# Altium schematic sheet 1.1.6_Interface_board_Sensors.SchDoc
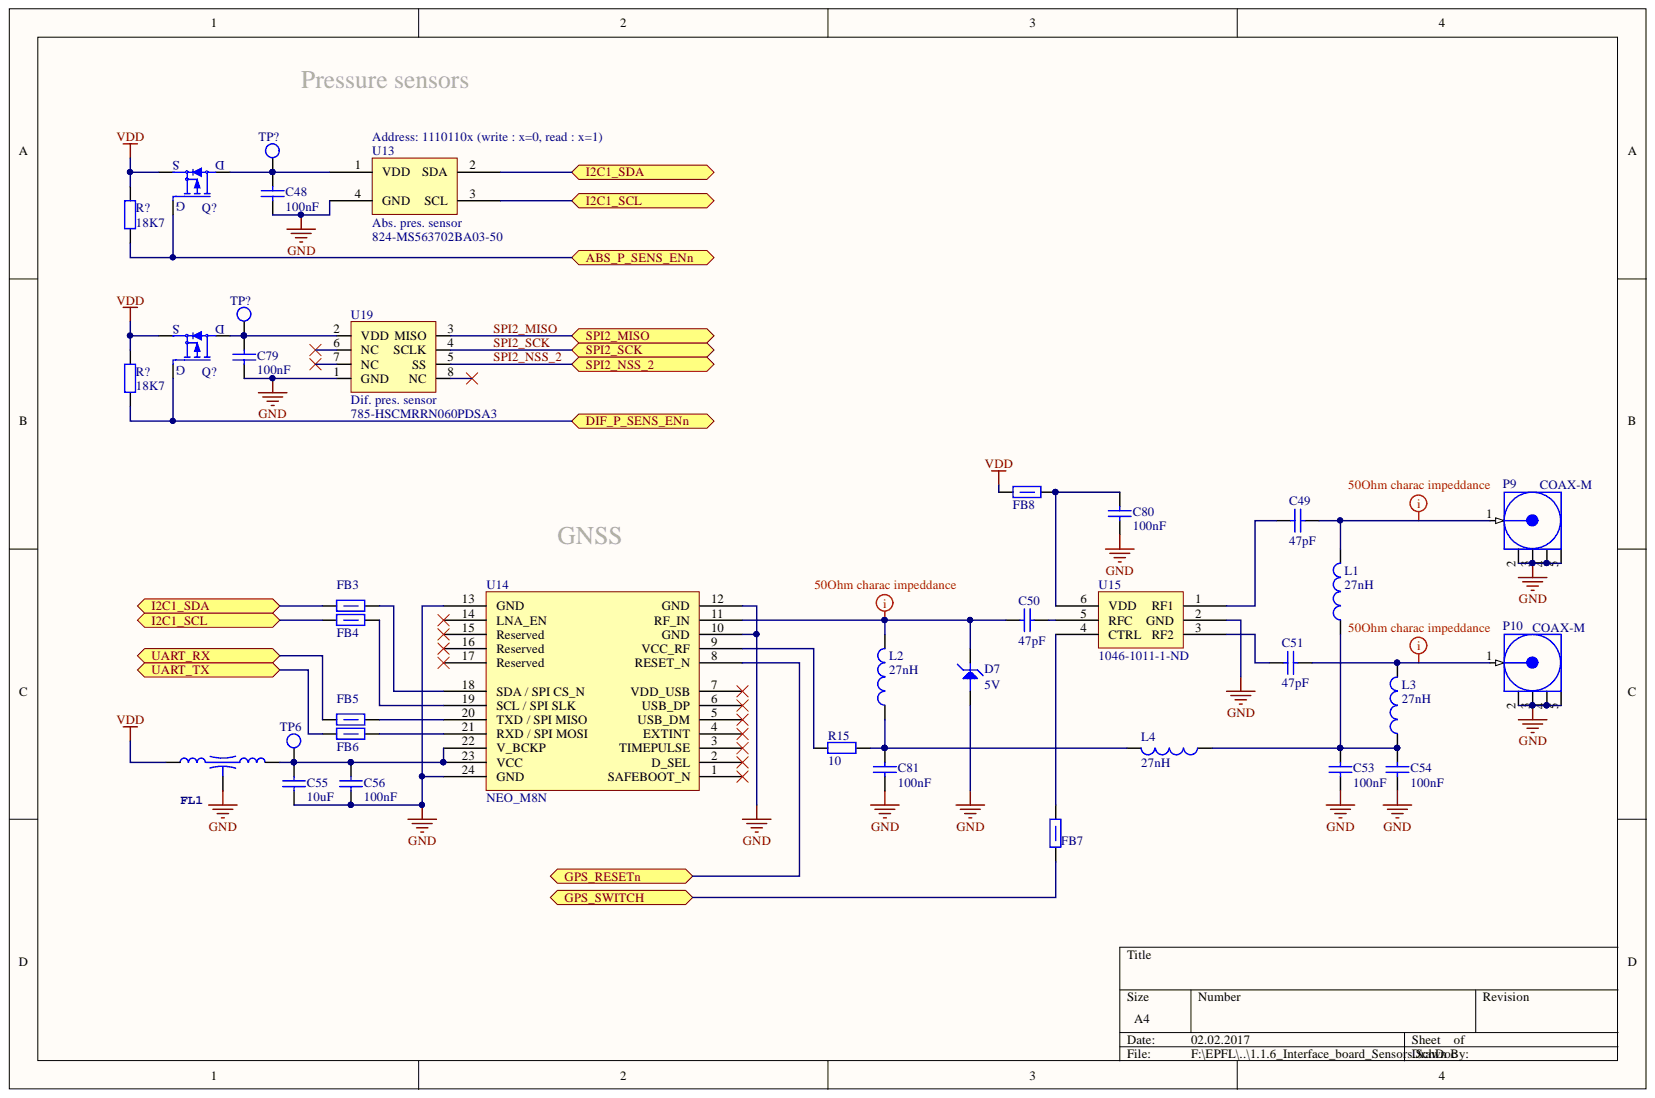
[diagram p0: 1.1.6_Interface_board_Sensors.SchDoc]

## Parts
C48  100nF  [CAP0603R]
C49  47pF  [CAP0603R]
C50  47pF  [CAP0603R]
C51  47pF  [CAP0603R]
C53  100nF  [CAP0603R]
C54  100nF  [CAP0603R]
C55  10uF  [CAP0805W]
C56  100nF  [CAP0603R]
C79  100nF  [CAP0603R]
C80  100nF  [CAP0603R]
C81  100nF  [CAP0603R]
D7  5V  [SOD-923]
FB3  81-BLM18KG601SZ1D  [0603]  (value not drawn)
FB4  81-BLM18KG601SZ1D  [0603]  (value not drawn)
FB5  81-BLM18KG601SZ1D  [0603]  (value not drawn)
FB6  81-BLM18KG601SZ1D  [0603]  (value not drawn)
FB7  81-BLM18KG601SZ1D  [0603]  (value not drawn)
FB8  81-BLM18KG601SZ1D  [0603]  (value not drawn)
FL1  NFE31P Series (1206 Size)   Chip EMIFILr LC Combined Type  [LCL_NFE31P]  (value not drawn)
L1  27nH  [0603]
L2  27nH  [0603]
L3  27nH  [0603]
L4  27nH  [0603]
P9  COAX-M  [MMCX2.54-V5]
P10  COAX-M  [MMCX2.54-V5]
Q?  MOSFET_P  [SOT23]  (value not drawn)
Q?  MOSFET_P  [SOT23]  (value not drawn)
R15  10  [0603]
R?  18K7  [0603]
R?  18K7  [0603]
TP6  Test point  [TP]  (value not drawn)
TP?  Test point  [TP]  (value not drawn)
TP?  Test point  [TP]  (value not drawn)
U13  Abs. pres. sensor  Supplier Part Number 1: 824-MS563702BA03-50  [SMD_3.0X3.0MM_4P]
U14  NEO_M8  Supplier Part Number 1: NEO_M8N  [NEO-8]
U15  Supplier Part Number 1: 1046-1011-1-ND  [SC-70]  (hidden comment: PE4259)
U19  Dif. pres. sensor  Supplier Part Number 1: 785-HSCMRRN060PDSA3  [HSCMRRN060PDSA3]

## Ports
ABS_P_SENS_ENN, DIF_P_SENS_ENN, GPS_RESETN, GPS_SWITCH, I2C1_SCL, I2C1_SDA, SPI2_MISO, SPI2_NSS_2, SPI2_SCK, UART_RX, UART_TX

## Nets
GND: C48.1 C53.1 C54.1 C55.1 C56.1 C79.1 C80.1 C81.1 D7.2 FL1.2 P9.2 P9.3 P9.4 P9.5 P10.2 P10.3 P10.4 P10.5 U13.4(GND) U14.10(GND) U14.12(GND) U14.13(GND) U14.24(GND) U15.2(GND) U19.1(GND)
VDD: FB8.2 FL1.1 Q?.2 R?.2
GPS_SWITCH: FB7.1 ; port GPS_SWITCH
I2C1_SCL: FB4.1 U13.3(SCL) ; port I2C1_SCL
I2C1_SDA: FB3.1 U13.2(SDA) ; port I2C1_SDA
SPI2_MISO: U19.3(MISO) ; port SPI2_MISO
SPI2_NSS_2: U19.5(SS) ; port SPI2_NSS_2
SPI2_SCK: U19.4(SCLK) ; port SPI2_SCK
UART_RX: FB5.1 ; port UART_RX
UART_TX: FB6.1 ; port UART_TX
NetC48_2: C48.2 Q?.3 TP?.1 U13.1(VDD)
NetC49_1: C49.1 L1.2 P9.1
NetC49_2: C49.2 U15.1(RF1)
NetC50_1: C50.1 U15.5(RFC)
NetC50_2: C50.2 D7.1 L2.2 U14.11(RF_IN)
NetC51_1: C51.1 L3.2 P10.1
NetC51_2: C51.2 U15.3(RF2)
NetC53_2: C53.2 C54.2 L1.1 L3.1 L4.1
NetC55_2: C55.2 C56.2 FL1.3 TP6.1 U14.22(V_BCKP) U14.23(VCC)
NetC79_2: C79.2 Q?.3 TP?.1 U19.2(VDD)
NetC80_2: C80.2 FB8.1 U15.6(VDD)
NetC81_2: C81.2 L2.1 L4.2 R15.2
NetFB3_2: FB3.2 U14.18(SDA_/_SPI_CS_N)
NetFB4_2: FB4.2 U14.19(SCL_/_SPI_SLK)
NetFB5_2: FB5.2 U14.20(TXD_/_SPI_MISO)
NetFB6_2: FB6.2 U14.21(RXD_/_SPI_MOSI)
NetFB7_2: FB7.2 U15.4(CTRL)
NetQ?_1: Q?.1 R?.1 ; port ABS_P_SENS_ENN, port DIF_P_SENS_ENN
NetR15_1: R15.1 U14.9(VCC_RF)
NetU14_8: U14.8(RESET_N) ; port GPS_RESETN

Not connected: U14 1(SAFEBOOT_N) 2(D_SEL) 3(TIMEPULSE) 4(EXTINT) 5(USB_DM) 6(USB_DP) 7(VDD_USB) 14(LNA_EN) 15(Reserved) 16(Reserved) 17(Reserved); U19 6(NC) 7(NC) 8(NC)

## Library data of the parts
C48 C53 C54 C56 C79-C81 = C_100nF: Capacitor; Component Kind: Standard; Signal Integrity: Cap; Simulation: CAP; Supplier 1: Mouser; Supplier Part Number 1: 81-GCG188R91H104KA1D; Library Name: Rocket_library.SchLib
C49-C51 = C_47pF_25V: Capacitor; Component Kind: Standard; Supplier 1: Mouser; Supplier Part Number 1: 77-VJ0603A470JXXPBC; Library Name: Rocket_library.SchLib
C55 = C_10uF_16v: Capacitor; Component Kind: Standard; Supplier 1: Mouser; Supplier Part Number 1: 81-GRT21BC81C106ME1L; Library Name: Rocket_library.SchLib
D7 = ESD9L5.0ST5G: Diodes TVS - Parasurtenseurs ESD; Component Kind: Standard; Supplier 1: Mouser; Supplier Part Number 1: 863-ESD9L5.0ST5G; Library Name: Rocket_library.SchLib
FB3-FB8 = Ferrite_bead: Component Kind: Standard; Supplier 1: Mouser; Supplier Part Number 1: 81-BLM18KG601SZ1D; Library Name: Rocket_library.SchLib
FL1 = NFE31P: Component Kind: Standard; Supplier 1: Mouser; Supplier Part Number 1: 81-NFE31PT222Z1E9L; Library Name: Rocket_library.SchLib
L1-L4 = L_27nH: Inductor; Component Kind: Standard; Supplier 1: Mouser; Supplier Part Number 1: 81-LQG18HN27NJ00D; Library Name: Rocket_library.SchLib
P9 P10 = COAX-M: RF Coaxial PCB Connector, MMCX; Thru-Hole, Vertical Mount Plug,  50 Ohm Impedance; DrillSize: Corresponds to nominal value.; PackageDocument: Rev. A, 16-Jun-1997; PCB_Layout: Complies with manufacturer's recommendation.; Component Kind: Standard; ComponentLink1Description: Manufacturer Link; ComponentLink1URL: http://www.amphenolrf.com/; DatasheetDocument: 30-May-2003; LatestRevisionDate: 15-Jul-2004; LatestRevisionNote: Component Replaced.; Library Name: Miscellaneous Connectors.IntLib; PackageReference: 908-21103; Published: 15-Jul-2004; Publisher: Altium Limited
Q? Q? = MOSFET_P_ 20V_1.5A: MOSFET 40V P-channel Trench MOSFET SOT-23-3; Component Kind: Standard; Supplier 1: Mouser; Supplier Part Number 1: 771-PMV250EPEAR; Library Name: Rocket_library.SchLib
R15 = R_0603_025W_10Ohm: 0.25W 10Ohm; Component Kind: Standard; Supplier 1: Mouser; Supplier Part Number 1: 667-ERJ-PA3J100V; Library Name: Rocket_library.SchLib
R? R? = R_0603_01W_18K7: 0.1W 18K7; Component Kind: Standard; Signal Integrity: Res; Simulation: RESISTOR; Supplier 1: Mouser; Supplier Part Number 1: 667-ERJ-3EKF1872V; Library Name: Rocket_library.SchLib
U13 = MS563702BA03-50: Pressure sensor; Component Kind: Standard; Supplier 1: Mouser; Library Name: Rocket_library.SchLib
U14 = uBlox_Neo M8: GNSS; Component Kind: Standard; Supplier 1: uBlox; Library Name: Rocket_library.SchLib
U15 = PE4259: RF SWITCH; Component Kind: Standard; Supplier 1: Digi-Key; Library Name: Rocket_library.SchLib
U19 = HSCMRRN060PDSA3: Pressure sensor; Component Kind: Standard; Supplier 1: Mouser; Library Name: Rocket_library.SchLib
TP6 TP? TP? = Test point: Component Kind: Standard; Library Name: Rocket_library.SchLib
